# Altium schematic sheet OA.SchDoc
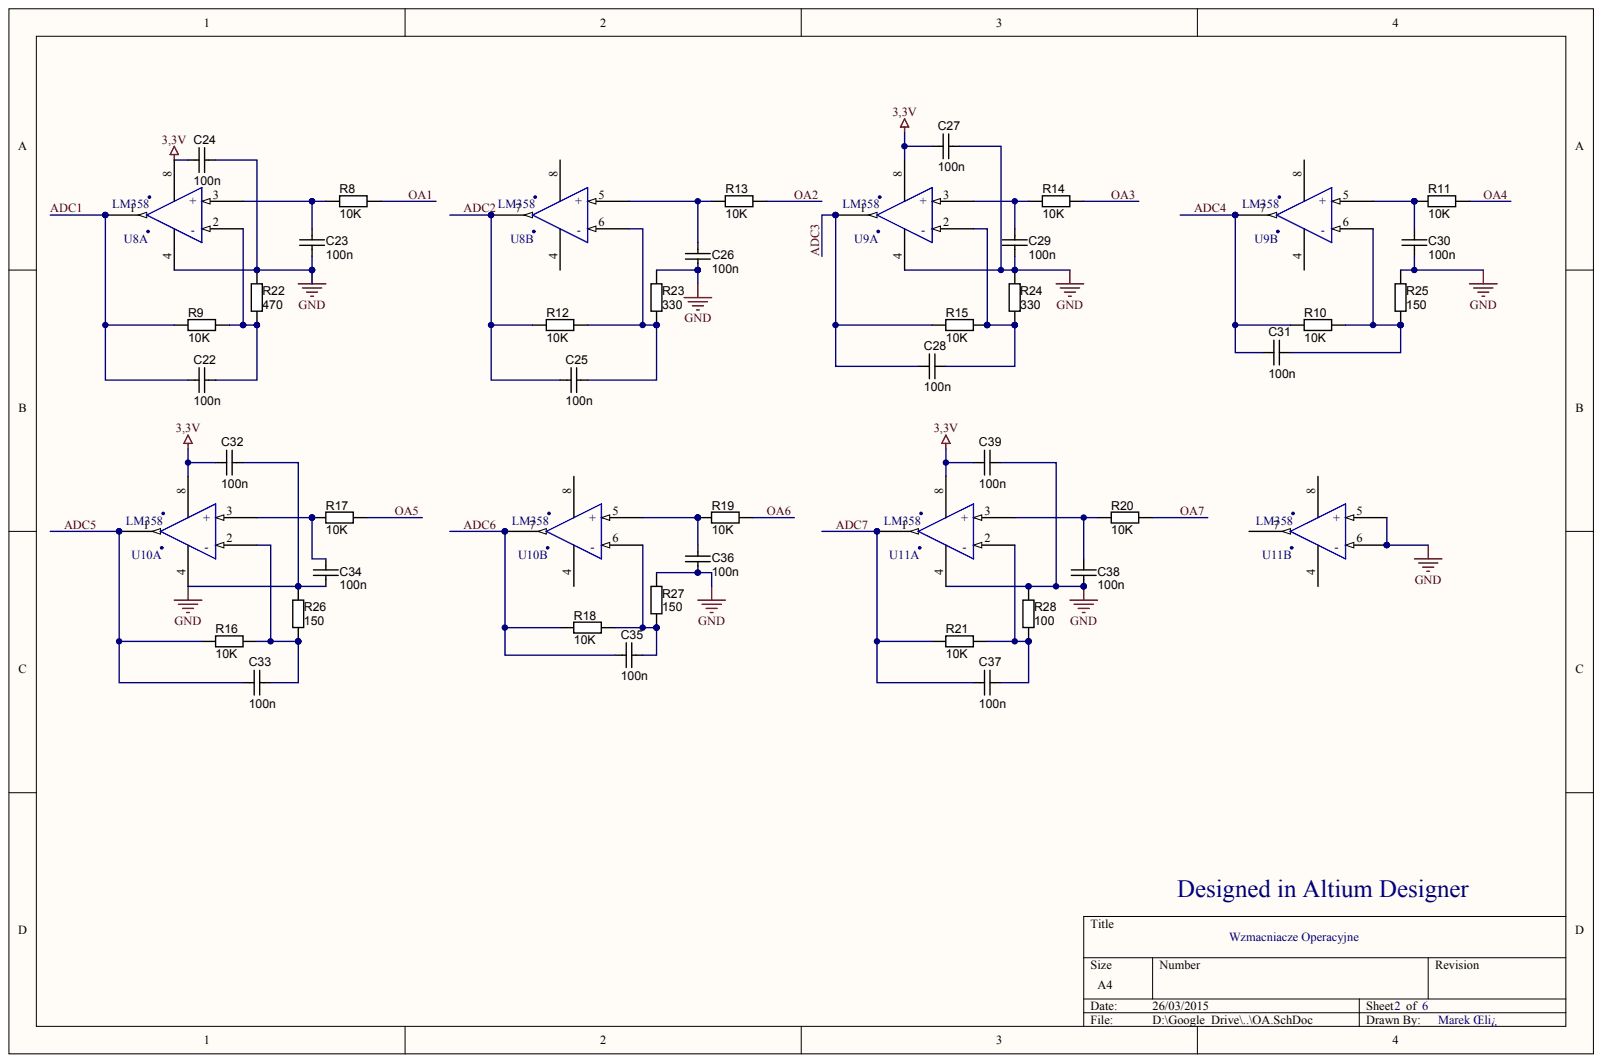
[diagram p0: OA.SchDoc]

## Parts
C22  value 100n  (hidden comment: approved sub OK)
C23  value 100n  (hidden comment: approved sub OK)
C24  value 100n  (hidden comment: approved sub OK)
C25  value 100n  (hidden comment: approved sub OK)
C26  value 100n  (hidden comment: approved sub OK)
C27  value 100n  (hidden comment: approved sub OK)
C28  value 100n  (hidden comment: approved sub OK)
C29  value 100n  (hidden comment: approved sub OK)
C30  value 100n  (hidden comment: approved sub OK)
C31  value 100n  (hidden comment: approved sub OK)
C32  value 100n  (hidden comment: approved sub OK)
C33  value 100n  (hidden comment: approved sub OK)
C34  value 100n  (hidden comment: approved sub OK)
C35  value 100n  (hidden comment: approved sub OK)
C36  value 100n  (hidden comment: approved sub OK)
C37  value 100n  (hidden comment: approved sub OK)
C38  value 100n  (hidden comment: approved sub OK)
C39  value 100n  (hidden comment: approved sub OK)
R8  value 10K  (hidden comment: Thick Film)
R9  value 10K  (hidden comment: Thick Film)
R10  value 10K  (hidden comment: Thick Film)
R11  value 10K  (hidden comment: Thick Film)
R12  value 10K  (hidden comment: Thick Film)
R13  value 10K  (hidden comment: Thick Film)
R14  value 10K  (hidden comment: Thick Film)
R15  value 10K  (hidden comment: Thick Film)
R16  value 10K  (hidden comment: Thick Film)
R17  value 10K  (hidden comment: Thick Film)
R18  value 10K  (hidden comment: Thick Film)
R19  value 10K  (hidden comment: Thick Film)
R20  value 10K  (hidden comment: Thick Film)
R21  value 10K  (hidden comment: Thick Film)
R22  value 470  (hidden comment: Thick Film)
R23  value 330  (hidden comment: Thick Film)
R24  value 330  (hidden comment: Thick Film)
R25  value 150  (hidden comment: Thick Film)
R26  value 150  (hidden comment: Thick Film)
R27  value 150  (hidden comment: Thick Film)
R28  value 100  (hidden comment: Thick Film)
U8  LM358  (parts A,B)
U9  LM358  (parts A,B)
U10  LM358  (parts A,B)
U11  LM358  (parts A,B)

## Nets
3,3V: C24.1 C27.1 C32.1 C39.1
GND: C23.2 C24.2 C26.1 C27.2 C29.2 C30.2 C32.2 C34.2 C36.2 C38.2 C39.2 R22.2 R23.2 R24.2 R25.2 R26.2 R27.2 R28.2 U11.5(+) U11.6(-)
ADC1: C22.1 R9.1 U8.1
ADC2: C25.1 R12.1 U8.7
ADC3: C28.1 R15.1 U9.1
ADC4: C31.1 R10.1 U9.7
ADC5: C33.1 R16.1 U10.1
ADC6: C35.1 R18.1 U10.7
ADC7: C37.1 R21.1 U11.1
OA1: R8.2
OA2: R13.2
OA3: R14.2
OA4: R11.2
OA5: R17.2
OA6: R19.2
OA7: R20.2
NetC22_2: C22.2 R9.2 R22.1 U8.2(-)
NetC23_1: C23.1 R8.1 U8.3(+)
NetC25_2: C25.2 R12.2 R23.1 U8.6(-)
NetC26_2: C26.2 R13.1 U8.5(+)
NetC28_2: C28.2 R15.2 R24.1 U9.2(-)
NetC29_1: C29.1 R14.1 U9.3(+)
NetC30_1: C30.1 R11.1 U9.5(+)
NetC31_2: C31.2 R10.2 R25.1 U9.6(-)
NetC33_2: C33.2 R16.2 R26.1 U10.2(-)
NetC34_1: C34.1 R17.1 U10.3(+)
NetC35_2: C35.2 R18.2 R27.1 U10.6(-)
NetC36_1: C36.1 R19.1 U10.5(+)
NetC37_2: C37.2 R21.2 R28.1 U11.2(-)
NetC38_1: C38.1 R20.1 U11.3(+)

Not connected: U8 4 8; U9 4 8; U10 4 8; U11 4 7 8

## Library data of the parts
C22-C39 = (no symbol name): Manufacturer 1: AVX Corporation; Manufacturer Part Number 1: 0805ZD106KAT2A; Tolerance: ±10%; Ratings: 10V; X5R; Material: ceramic; Package / Case: 0805 (2012 metric); Manufacturer 2: Kemet; Manufacturer Part Number 2: C0805C106K8PACTU; Supplier 1: Digi-Key; Supplier Part Number 1: 478-5167-1-ND; ComponentLink1URL: http://www.avx.com/docs/Catalogs/cx5r.pdf; ComponentLink1Description: http://www.avx.com/docs/Catalogs/cx5r.pdf; ComponentLink2URL: http://www.kemet.com/datasheets&C0805C106K8PACTU; ComponentLink2Description: http://www.kemet.com/datasheets&C0805C106K8PACTU; Supplier 2: Digi-Key; Supplier Part Number 2: 399-4925-1-ND
R8-R28 = (no symbol name): Manufacturer 1: Rohm Semiconductor; Manufacturer Part Number 1: MCR10EZPJ000; Tolerance: ±5%; Ratings: 1/8W; Package / Case: 0805 (2012 metric)
